# Altium schematic sheet MCS_diag_ps.SchDoc(MCS_diag_ps)
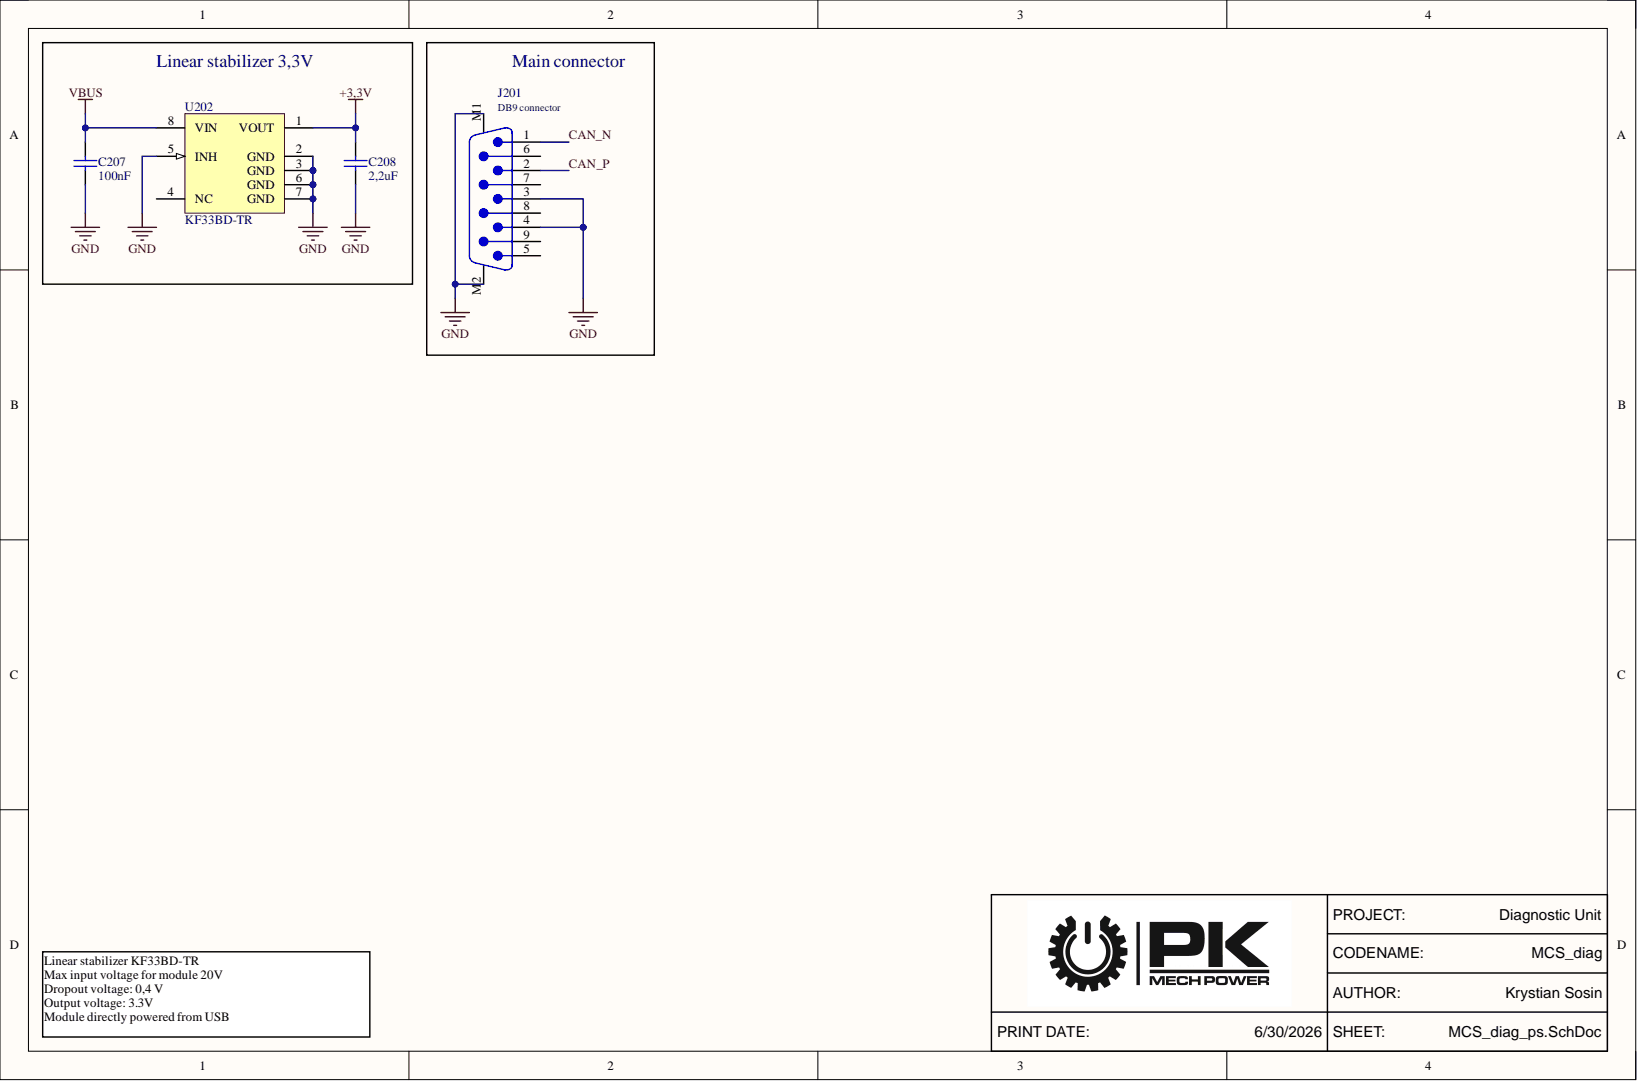
[diagram p0: MCS_diag_ps.SchDoc]

## Parts
C207  value 100nF  (hidden comment: Capacitor 0603)
C208  value 2,2uF  (hidden comment: Capacitor 0603)
J201  DB9 connector
U202  KF33BD-TR

## Nets
+3,3V: C208.2 U202.1(VOUT)
GND: C207.1 C208.1 J201.3 J201.4 J201.M1 J201.M2 U202.2(GND) U202.3(GND) U202.5(INH) U202.6(GND) U202.7(GND)
VBUS: C207.2 U202.8(VIN)
CAN_N: J201.1
CAN_P: J201.2

Not connected: J201 5 6 7 8 9; U202 4(NC)

## Library data of the parts
C207 C208 = CMP-001-00008-4: SMD 0603 capacitor; Component Kind: Standard; Library Name: EMEA- PK MechPower-  Cracow University of Technology
J201 = CMP-00035-1: D Sub Connector, Right Angle, R/a Pcb Mount D Sub, De, Plug, 9, Solder Rohs Compliant: Yes; Component Kind: Standard; Library Name: EMEA- PK MechPower-  Cracow University of Technology
U202 = CMP-001-0002-2: KF33 Series 500 mA 3.3 V Fixed Output VLDO Regulator with Inhibit - SOIC-8; Component Kind: Standard; Library Name: EMEA- PK MechPower-  Cracow University of Technology
